# Altium schematic sheet Poseidon.SchDoc
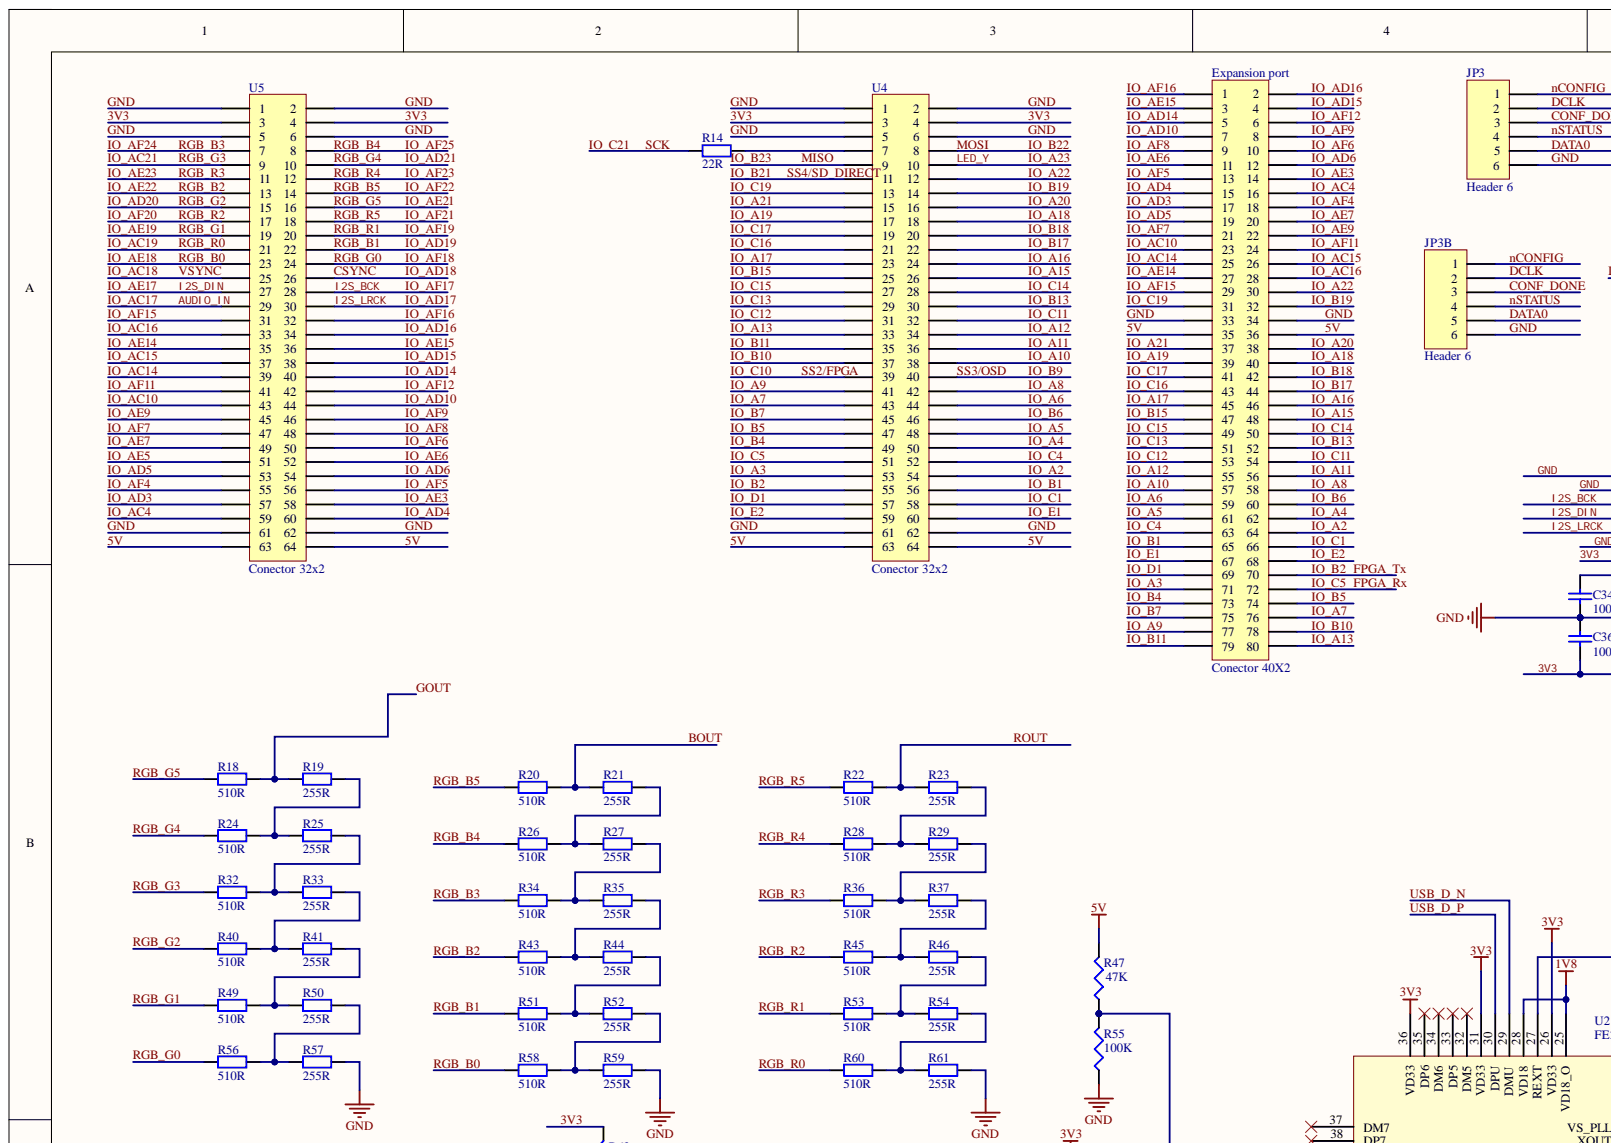
[diagram p0: Poseidon.SchDoc, top left]
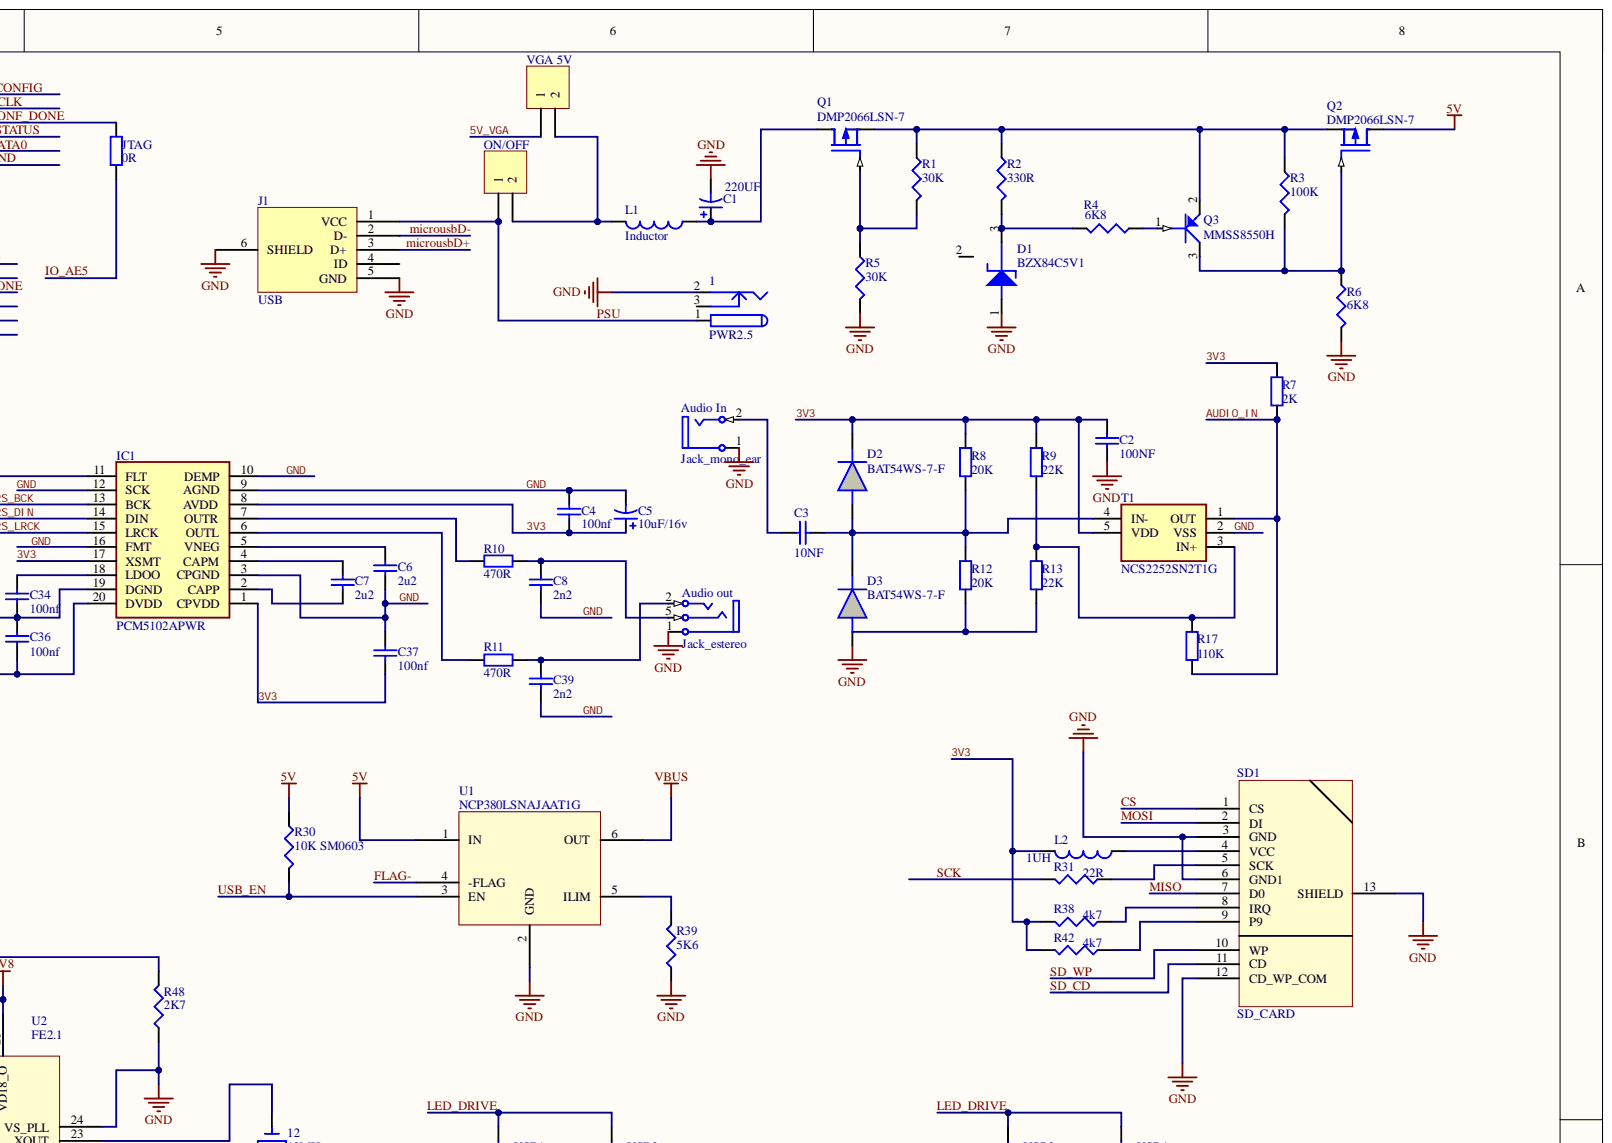
[diagram p1: Poseidon.SchDoc, top right]
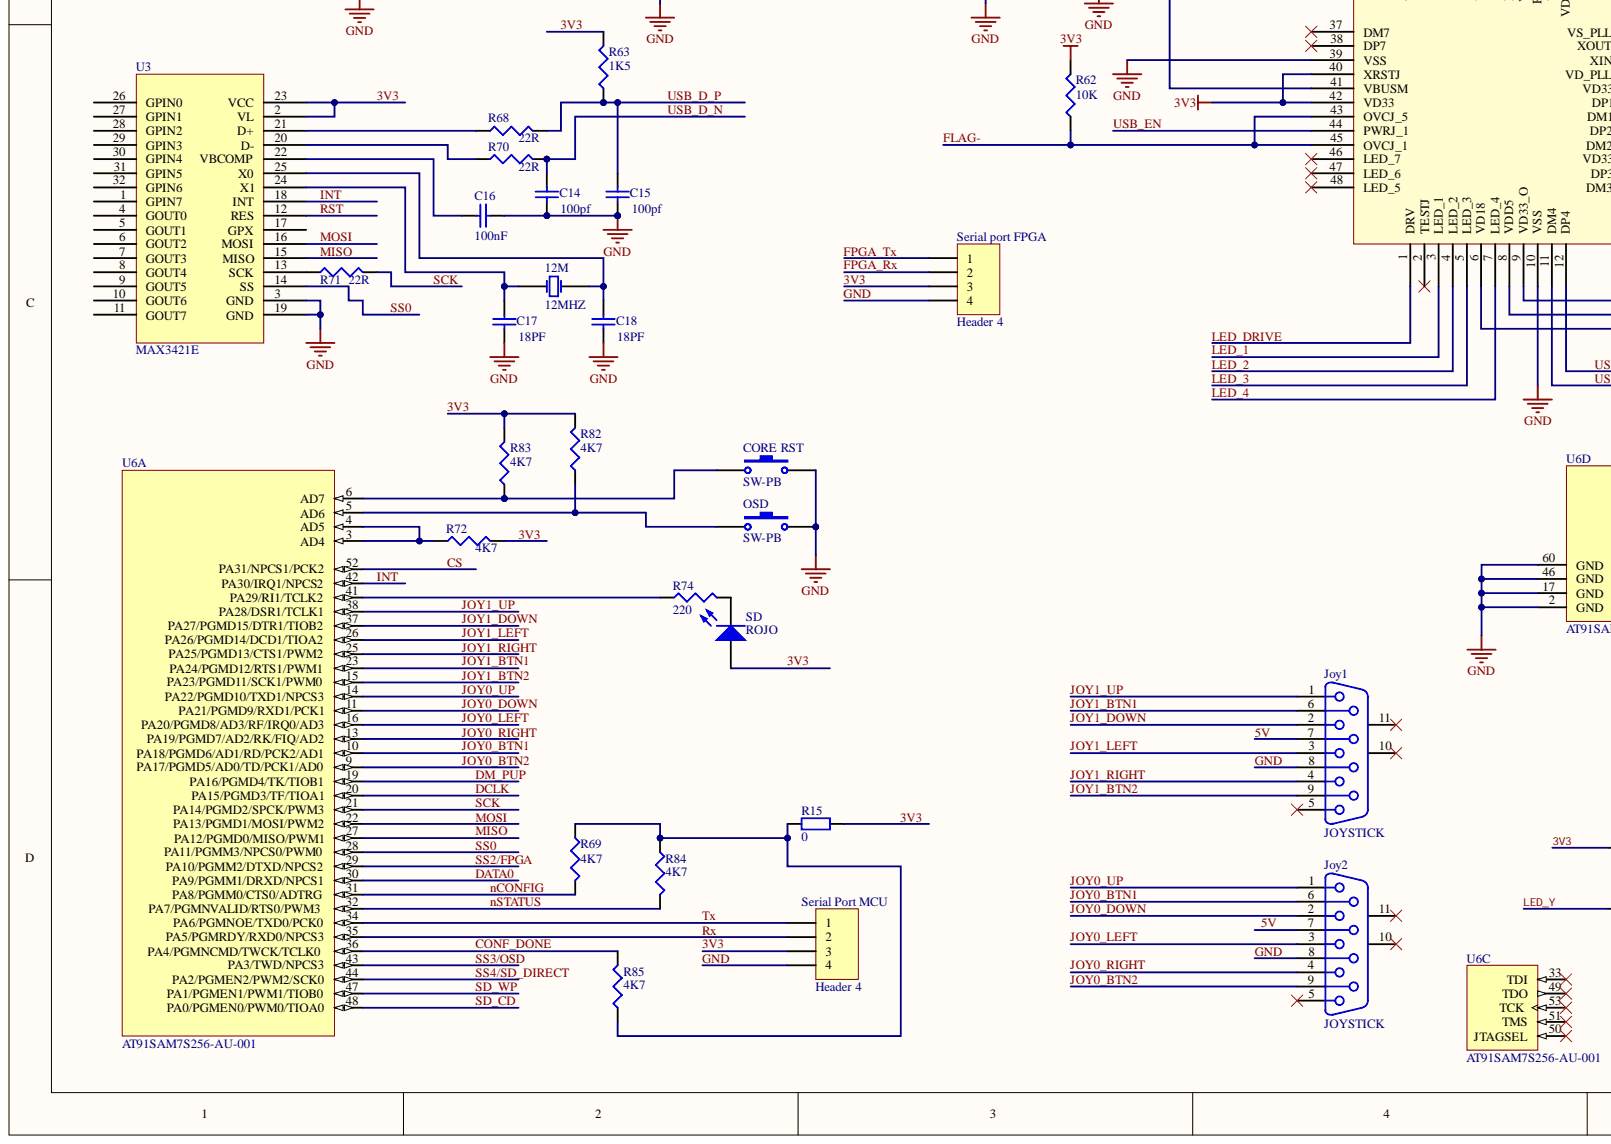
[diagram p2: Poseidon.SchDoc, bottom left]
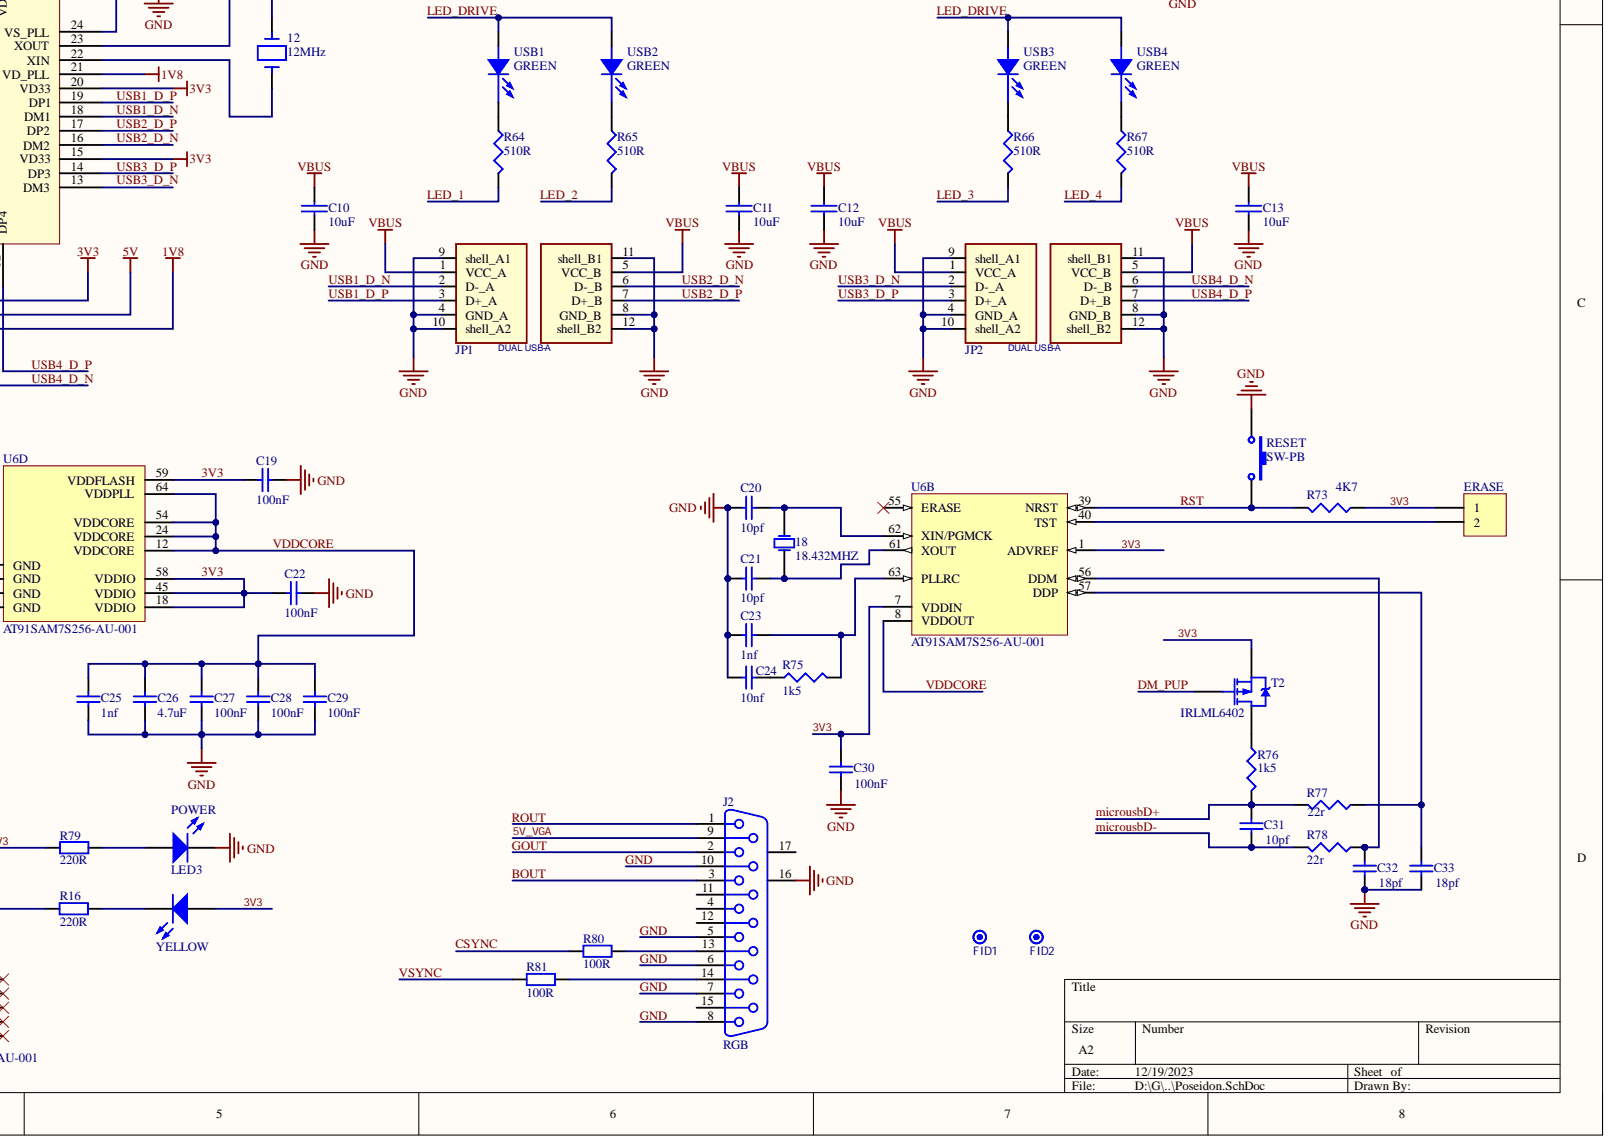
[diagram p3: Poseidon.SchDoc, bottom right]

## Parts
1  PWR2.5  [DCJACK]
12  12MHz  [HC49/4H_SMX]
12M  12MHZ  [HC49/4H_SMX]
18  18.432MHZ  [HC49/4H_SMX]
Audio_In  Jack_mono_ear  [AUDIOJACK_MANU]
Audio_out  Jack_estereo  [AUDIOJACK_MANU]
C1  220UF  [smd_elec6mm]
C2  100NF  [cap0603_manu3d]
C3  10NF  [cap0603_manu3d]
C4  100nf  [cap0603_manu3d]
C5  10uF/16v  [smd_elec3.5mm]
C6  2u2  [cap0603_manu3d]
C7  2u2  [cap0603_manu3d]
C8  2n2  [cap0603_manu3d]
C10  10uF  [SM0805]
C11  10uF  [SM0805]
C12  10uF  [SM0805]
C13  10uF  [SM0805]
C14  100pf  [cap0603_manu3d]
C15  100pf  [cap0603_manu3d]
C16  100nF  [cap0603_manu3d]
C17  18PF  [cap0603_manu3d]
C18  18PF  [cap0603_manu3d]
C19  100nF  [cap0603_manu3d]
C20  10pf  [cap0603_manu3d]
C21  10pf  [cap0603_manu3d]
C22  100nF  [cap0603_manu3d]
C23  1nf  [cap0603_manu3d]
C24  10nf  [cap0603_manu3d]
C25  1nf  [cap0603_manu3d]
C26  4.7uF  [cap0603_manu3d]
C27  100nF  [cap0603_manu3d]
C28  100nF  [cap0603_manu3d]
C29  100nF  [cap0603_manu3d]
C30  100nF  [cap0603_manu3d]
C31  10pf  [cap0603_manu3d]
C32  18pf  [cap0603_manu3d]
C33  18pf  [cap0603_manu3d]
C34  100nf  [cap0603_manu3d]
C36  100nf  [cap0603_manu3d]
C37  100nf  [cap0603_manu3d]
C39  2n2  [cap0603_manu3d]
CORE_RST  SW-PB  [TACTSWITCH90]
D1  BZX84C5V1  [SOT23]
D2  BAT54WS-7-F  [SOD2513X120N]
D3  BAT54WS-7-F  [SOD2513X120N]
ERASE  Header 2  [Pin_2v_2.56]  (value not drawn)
Expansion_port  Conector 40X2  [DIP80]
FID1  =Value  [FID_round_1mm]  (no BOM)
FID2  =Value  [FID_round_1mm]  (no BOM)
IC1  PCM5102APWR  [SOP65P640X120-20N]
J1  USB  [Micro_usb__manu]
J2  RGB  [VGA]
Joy1  JOYSTICK  [DSUB1.385-2H9B]
Joy2  JOYSTICK  [DSUB1.385-2H9B]
JP1  DUAL USB-A  [USB_STACK]
JP2  DUAL USB-A  [USB_STACK]
JP3  Header 6  [HDR1X6]
JP3B  Header 6  [HDR1X6]
JTAG  0R  [Resistor_bridge]
L1  Inductor  [RES0603_manu3d]
L2  Inductor  value 1UH  [RES0603_manu3d]
ON/OFF  Header 2  [Pin_2v_2.56]  (value not drawn)
OSD  SW-PB  [TACTSWITCH90]
POWER  LED3  [LED0805_manu]
Q1  DMP2066LSN-7  [SOT23]
Q2  DMP2066LSN-7  [SOT23]
Q3  MMSS8550H  [SOT23]
R1  30K  [RES0603_manu3d]
R2  330R  [RES0603_manu3d]
R3  100K  [RES0603_manu3d]
R4  6K8  [RES0603_manu3d]
R5  30K  [RES0603_manu3d]
R6  6K8  [RES0603_manu3d]
R7  2K  [RES0603_manu3d]
R8  20K  [RES0603_manu3d]
R9  22K  [RES0603_manu3d]
R10  470R  [RES0603_manu3d]
R11  470R  [RES0603_manu3d]
R12  20K  [RES0603_manu3d]
R13  22K  [RES0603_manu3d]
R14  22R  [RES0603_manu3d]
R15  0  [Resistor_bridge]
R16  220R  [RES0603_manu3d]
R17  110K  [RES0603_manu3d]
R18  510R  [RES0603_manu3d]
R19  255R  [RES0603_manu3d]
R20  510R  [RES0603_manu3d]
R21  255R  [RES0603_manu3d]
R22  510R  [RES0603_manu3d]
R23  255R  [RES0603_manu3d]
R24  510R  [RES0603_manu3d]
R25  255R  [RES0603_manu3d]
R26  510R  [RES0603_manu3d]
R27  255R  [RES0603_manu3d]
R28  510R  [RES0603_manu3d]
R29  255R  [RES0603_manu3d]
R30  10K SM0603  [RES0603_manu3d]
R31  value 22R  [RES0603_manu3d]  (hidden comment: Res3)
R32  510R  [RES0603_manu3d]
R33  255R  [RES0603_manu3d]
R34  510R  [RES0603_manu3d]
R35  255R  [RES0603_manu3d]
R36  510R  [RES0603_manu3d]
R37  255R  [RES0603_manu3d]
R38  value 4k7  [RES0603_manu3d]  (hidden comment: Res3)
R39  5K6  [RES0603_manu3d]
R40  510R  [RES0603_manu3d]
R41  255R  [RES0603_manu3d]
R42  value 4k7  [RES0603_manu3d]  (hidden comment: Res3)
R43  510R  [RES0603_manu3d]
R44  255R  [RES0603_manu3d]
R45  510R  [RES0603_manu3d]
R46  255R  [RES0603_manu3d]
R47  47K  [RES0603_manu3d]
R48  2K7  [RES0603_manu3d]
R49  510R  [RES0603_manu3d]
R50  255R  [RES0603_manu3d]
R51  510R  [RES0603_manu3d]
R52  255R  [RES0603_manu3d]
R53  510R  [RES0603_manu3d]
R54  255R  [RES0603_manu3d]
R55  100K  [RES0603_manu3d]
R56  510R  [RES0603_manu3d]
R57  255R  [RES0603_manu3d]
R58  510R  [RES0603_manu3d]
R59  255R  [RES0603_manu3d]
R60  510R  [RES0603_manu3d]
R61  255R  [RES0603_manu3d]
R62  10K  [RES0603_manu3d]
R63  1K5  [RES0603_manu3d]
R64  510R  [RES0603_manu3d]
R65  510R  [RES0603_manu3d]
R66  510R  [RES0603_manu3d]
R67  510R  [RES0603_manu3d]
R68  22R  [RES0603_manu3d]
R69  4K7  [RES0603_manu3d]
R70  22R  [RES0603_manu3d]
R71  22R  [RES0603_manu3d]
R72  4K7  [RES0603_manu3d]
R73  4K7  [RES0603_manu3d]
R74  220  [RES0603_manu3d]
R75  1k5  [RES0603_manu3d]
R76  1k5  [RES0603_manu3d]
R77  22r  [RES0603_manu3d]
R78  value 22r  [RES0603_manu3d]  (hidden comment: Res3)
R79  220R  [RES0603_manu3d]
R80  100R  [RES0603_manu3d]
R81  100R  [RES0603_manu3d]
R82  4K7  [RES0603_manu3d]
R83  4K7  [RES0603_manu3d]
R84  4K7  [RES0603_manu3d]
R85  4K7  [RES0603_manu3d]
RESET  SW-PB  [SWITCH]
SD  ROJO  [LED0805R]
SD1  SD_CARD  [sdsocket]
Serial_port_FPGA  Header 4  [HDR1X4]
Serial_Port_MCU  Header 4  [HDR1X4]
T1  NCS2252SN2T1G  [SOT95P275X110-5N]
T2  IRLML6402  [SOT23_L]
U1  NCP380LSNAJAAT1G  [SOT23-6LEAD]
U2  FE2.1  [LQFP48]
U3  MAX3421E  [TQFP0.5]
U4  Conector 32x2  [DIP64]
U5  Conector 32x2  [DIP64]
U6  AT91SAM7S256-AU-001  [LQFP64_N]  (parts A,B,C,D)
USB1  GREEN  [LED0805_manu]
USB2  GREEN  [LED0805_manu]
USB3  GREEN  [LED0805_manu]
USB4  GREEN  [LED0805_manu]
VGA_5V  Header 2  [Pin_2v_2.56]  (value not drawn)
YELLOW  LED3  [LED0805R]

## Nets
1V8: U2.6(VD18) U2.21(VD_PLL) U2.25(VD18_O) U2.28(VD18)
VBUS: C10.1 C11.1 C12.1 C13.1 JP1.1(VCC_A) JP1.5(VCC_B) JP2.1(VCC_A) JP2.5(VCC_B) U1.6(OUT)
3V3: C2.1 C4.2 C5.1 C19.2 C22.2 C30.2 C36.2 C37.1 D2.1 ERASE.1 IC1.1(CPVDD) IC1.8(AVDD) IC1.17(XSMT) IC1.20(DVDD) L2.2 R7.2 R8.2 R9.2 R15.2 R38.2 R42.2 R62.2 R63.2 R72.2 R73.1 R79.1 R82.2 R83.2 SD.1 Serial_port_FPGA.3 Serial_Port_MCU.3 T1.5(VDD) T2.2 U2.9(VD33_O) U2.15(VD33) U2.20(VD33) U2.26(VD33) U2.31(VD33) U2.36(VD33) U2.40(XRSTJ) U2.42(VD33) U3.2(VL) U3.23(VCC) U4.3 U4.4 U5.3 U5.4 U6.1(ADVREF) U6.7(VDDIN) U6.18(VDDIO) U6.45(VDDIO) U6.58(VDDIO) U6.59(VDDFLASH) YELLOW.1
5V: Expansion_port.35 Expansion_port.36 Joy1.7 Joy2.7 Q2.3 R30.1 R47.2 U1.1(IN) U2.8(VDD5) U4.63 U4.64 U5.63 U5.64
5V_VGA: J2.9 VGA_5V.1
AUDIO_IN: R7.1 R17.1 T1.1(OUT) U4.29
BOUT: J2.3 R20.2 R21.1
CONF_DONE: JP3.3 JP3B.3 JTAG.2 R85.2 U6.36(PA4/PGMNCMD/TWCK/TCLK0)
CS: SD1.1(CS) U6.52(PA31/NPCS1/PCK2)
CSYNC: R80.1 U4.26
DATA0: JP3.5 JP3B.5 U6.30(PA9/PGMM1/DRXD/NPCS1)
DCLK: JP3.2 JP3B.2 U6.20(PA15/PGMD3/TF/TIOA1)
DM_PUP: T2.1 U6.19(PA16/PGMD4/TK/TIOB1)
FLAG-: R62.1 U1.4(-FLAG) U2.43(OVCJ_5) U2.45(OVCJ_1)
FPGA_Rx: Expansion_port.72 Serial_port_FPGA.2 U5.51
FPGA_Tx: Expansion_port.70 Serial_port_FPGA.1 U5.55
GND: 1.2 Audio_In.1 Audio_out.1 C1.2 C2.2 C4.1 C5.2 C6.2 C8.2 C10.2 C11.2 C12.2 C13.2 C14.1 C15.1 C16.1 C17.1 C18.1 C19.1 C20.2 C21.2 C22.1 C23.2 C24.2 C25.2 C26.2 C27.2 C28.2 C29.2 C30.1 C32.1 C33.1 C34.2 C36.1 C37.2 C39.2 CORE_RST.2 D1.1 D3.2 Expansion_port.33 Expansion_port.34 IC1.3(CPGND) IC1.9(AGND) IC1.10(DEMP) IC1.11(FLT) IC1.12(SCK) IC1.16(FMT) IC1.19(DGND) J1.5(GND) J1.6(SHIELD) J2.5 J2.6 J2.7 J2.8 J2.10 J2.16 Joy1.8 Joy2.8 JP1.4(GND_A) JP1.8(GND_B) JP1.9(shell_A1) JP1.10(shell_A2) JP1.11(shell_B1) JP1.12(shell_B2) JP2.4(GND_A) JP2.8(GND_B) JP2.9(shell_A1) JP2.10(shell_A2) JP2.11(shell_B1) JP2.12(shell_B2) JP3.6 JP3B.6 OSD.2 POWER.2 R5.2 R6.2 R39.1 R48.1 R55.1 R57.2 R59.2 R61.2 RESET.1 SD1.3(GND) SD1.6(GND1) SD1.12(CD_WP_COM) SD1.13(SHIELD) Serial_port_FPGA.4 Serial_Port_MCU.4 T1.2(VSS) U1.2(GND) U2.10(VSS) U2.24(VS_PLL) U2.39(VSS) U3.3(GND) U3.19(GND) U4.1 U4.2 U4.5 U4.6 U4.61 U4.62 U5.1 U5.2 U5.5 U5.6 U5.61 U5.62 U6.2(GND) U6.17(GND) U6.46(GND) U6.60(GND)
GOUT: J2.2 R18.2 R19.1
I2S_BCK: IC1.13(BCK) U4.28
I2S_DIN: IC1.14(DIN) U4.27
I2S_LRCK: IC1.15(LRCK) U4.30
INT: U3.18(INT) U6.42(PA30/IRQ1/NPCS2)
IO_A2: Expansion_port.64 U5.54
IO_A3: Expansion_port.71 U5.53
IO_A4: Expansion_port.62 U5.50
IO_A5: Expansion_port.61 U5.48
IO_A6: Expansion_port.59 U5.44
IO_A7: Expansion_port.76 U5.43
IO_A8: Expansion_port.58 U5.42
IO_A9: Expansion_port.77 U5.41
IO_A10: Expansion_port.57 U5.38
IO_A11: Expansion_port.56 U5.36
IO_A12: Expansion_port.55 U5.34
IO_A13: Expansion_port.80 U5.33
IO_A15: Expansion_port.48 U5.26
IO_A16: Expansion_port.46 U5.24
IO_A17: Expansion_port.45 U5.23
IO_A18: Expansion_port.40 U5.18
IO_A19: Expansion_port.39 U5.17
IO_A20: Expansion_port.38 U5.16
IO_A21: Expansion_port.37 U5.15
IO_A22: Expansion_port.30 U5.12
IO_A23: R16.1 U5.10
IO_AC4: Expansion_port.16 U4.59
IO_AC10: Expansion_port.23 U4.43
IO_AC14: Expansion_port.25 U4.39
IO_AC15: Expansion_port.26 U4.37
IO_AC16: Expansion_port.28 U4.33
IO_AC18: R81.1 U4.25
IO_AC19: R60.1 U4.21
IO_AC21: R32.1 U4.9
IO_AD3: Expansion_port.17 U4.57
IO_AD4: Expansion_port.15 U4.60
IO_AD5: Expansion_port.19 U4.53
IO_AD6: Expansion_port.12 U4.54
IO_AD10: Expansion_port.7 U4.44
IO_AD14: Expansion_port.5 U4.40
IO_AD15: Expansion_port.4 U4.38
IO_AD16: Expansion_port.2 U4.34
IO_AD19: R51.1 U4.22
IO_AD20: R40.1 U4.15
IO_AD21: R24.1 U4.10
IO_AE3: Expansion_port.14 U4.58
IO_AE5: JTAG.1 U4.51
IO_AE6: Expansion_port.11 U4.52
IO_AE7: Expansion_port.20 U4.49
IO_AE9: Expansion_port.22 U4.45
IO_AE14: Expansion_port.27 U4.35
IO_AE15: Expansion_port.3 U4.36
IO_AE18: R58.1 U4.23
IO_AE19: R49.1 U4.19
IO_AE21: R18.1 U4.16
IO_AE22: R43.1 U4.13
IO_AE23: R36.1 U4.11
IO_AF4: Expansion_port.18 U4.55
IO_AF5: Expansion_port.13 U4.56
IO_AF6: Expansion_port.10 U4.50
IO_AF7: Expansion_port.21 U4.47
IO_AF8: Expansion_port.9 U4.48
IO_AF9: Expansion_port.8 U4.46
IO_AF11: Expansion_port.24 U4.41
IO_AF12: Expansion_port.6 U4.42
IO_AF15: Expansion_port.29 U4.31
IO_AF16: Expansion_port.1 U4.32
IO_AF18: R56.1 U4.24
IO_AF19: R53.1 U4.20
IO_AF20: R45.1 U4.17
IO_AF21: R22.1 U4.18
IO_AF22: R20.1 U4.14
IO_AF23: R28.1 U4.12
IO_AF24: R34.1 U4.7
IO_AF25: R26.1 U4.8
IO_B1: Expansion_port.65 U5.56
IO_B4: Expansion_port.73 U5.49
IO_B5: Expansion_port.74 U5.47
IO_B6: Expansion_port.60 U5.46
IO_B7: Expansion_port.75 U5.45
IO_B9: U5.40 U6.43(PA3/TWD/NPCS3)
IO_B10: Expansion_port.78 U5.37
IO_B11: Expansion_port.79 U5.35
IO_B13: Expansion_port.52 U5.30
IO_B15: Expansion_port.47 U5.25
IO_B17: Expansion_port.44 U5.22
IO_B18: Expansion_port.42 U5.20
IO_B19: Expansion_port.32 U5.14
IO_B21: U5.11 U6.44(PA2/PGMEN2/PWM2/SCK0)
IO_B22: SD1.2(DI) U3.16(MOSI) U5.8 U6.22(PA13/PGMD1/MOSI/PWM2)
IO_B23: SD1.7(D0) U3.15(MISO) U5.9 U6.27(PA12/PGMD0/MISO/PWM1)
IO_C1: Expansion_port.66 U5.58
IO_C4: Expansion_port.63 U5.52
IO_C10: U5.39 U6.29(PA10/PGMM2/DTXD/NPCS2)
IO_C11: Expansion_port.54 U5.32
IO_C12: Expansion_port.53 U5.31
IO_C13: Expansion_port.51 U5.29
IO_C14: Expansion_port.50 U5.28
IO_C15: Expansion_port.49 U5.27
IO_C16: Expansion_port.43 U5.21
IO_C17: Expansion_port.41 U5.19
IO_C19: Expansion_port.31 U5.13
IO_C21: R14.1 R31.2 R71.2 U6.21(PA14/PGMD2/SPCK/PWM3)
IO_D1: Expansion_port.69 U5.57
IO_E1: Expansion_port.67 U5.60
IO_E2: Expansion_port.68 U5.59
JOY0_BTN1: Joy2.6 U6.10(PA18/PGMD6/AD1/RD/PCK2/AD1)
JOY0_BTN2: Joy2.9 U6.9(PA17/PGMD5/AD0/TD/PCK1/AD0)
JOY0_DOWN: Joy2.2 U6.11(PA21/PGMD9/RXD1/PCK1)
JOY0_LEFT: Joy2.3 U6.16(PA20/PGMD8/AD3/RF/IRQ0/AD3)
JOY0_RIGHT: Joy2.4 U6.13(PA19/PGMD7/AD2/RK/FIQ/AD2)
JOY0_UP: Joy2.1 U6.14(PA22/PGMD10/TXD1/NPCS3)
JOY1_BTN1: Joy1.6 U6.23(PA24/PGMD12/RTS1/PWM1)
JOY1_BTN2: Joy1.9 U6.15(PA23/PGMD11/SCK1/PWM0)
JOY1_DOWN: Joy1.2 U6.37(PA27/PGMD15/DTR1/TIOB2)
JOY1_LEFT: Joy1.3 U6.26(PA26/PGMD14/DCD1/TIOA2)
JOY1_RIGHT: Joy1.4 U6.25(PA25/PGMD13/CTS1/PWM2)
JOY1_UP: Joy1.1 U6.38(PA28/DSR1/TCLK1)
LED_1: R64.2 U2.3(LED_1)
LED_2: R65.2 U2.4(LED_2)
LED_3: R66.2 U2.5(LED_3)
LED_4: R67.2 U2.7(LED_4)
LED_DRIVE: U2.1(DRV) USB1.1 USB2.1 USB3.1 USB4.1
microusbD+: C31.2 J1.3(D+) R76.2 R77.2
microusbD-: C31.1 J1.2(D-) R78.2
nCONFIG: JP3.1 JP3B.1 R69.2 U6.31(PA8/PGMM0/CTS0/ADTRG)
nSTATUS: JP3.4 JP3B.4 R84.2 U6.32(PA7/PGMNVALID/RTS0/PWM3)
PSU: 1.1 J1.1(VCC) ON/OFF.1
ROUT: J2.1 R22.2 R23.1
RST: R73.2 RESET.2 U3.12(RES) U6.39(NRST)
Rx: Serial_Port_MCU.2 U6.35(PA5/PGMRDY/RXD0/NPCS3)
SD_CD: SD1.11(CD) U6.48(PA0/PGMEN0/PWM0/TIOA0)
SD_WP: SD1.10(WP) U6.47(PA1/PGMEN1/PWM1/TIOB0)
SS0: U3.14(SS) U6.28(PA11/PGMM3/NPCS0/PWM0)
Tx: Serial_Port_MCU.1 U6.34(PA6/PGMNOE/TXD0/PCK0)
USB1_D_N: JP1.2(D-_A) U2.18(DM1)
USB1_D_P: JP1.3(D+_A) U2.19(DP1)
USB2_D_N: JP1.6(D-_B) U2.16(DM2)
USB2_D_P: JP1.7(D+_B) U2.17(DP2)
USB3_D_N: JP2.2(D-_A) U2.13(DM3)
USB3_D_P: JP2.3(D+_A) U2.14(DP3)
USB4_D_N: JP2.6(D-_B) U2.11(DM4)
USB4_D_P: JP2.7(D+_B) U2.12(DP4)
USB_D_N: C14.2 R70.2 U2.29(DMU)
USB_D_P: C15.2 R63.1 R68.2 U2.30(DPU)
USB_EN: R30.2 U1.3(EN) U2.44(PWRJ_1)
VDDCORE: C25.1 C26.1 C27.1 C28.1 C29.1 U6.8(VDDOUT) U6.12(VDDCORE) U6.24(VDDCORE) U6.54(VDDCORE) U6.64(VDDPLL)
Net12_1: 12.1 U2.23(XOUT)
Net12_2: 12.2 U2.22(XIN)
Net12M_1: 12M.1 C18.2 U3.25(X0)
Net12M_2: 12M.2 C17.2 U3.24(X1)
Net18_1: 18.1 C21.1 U6.61(XOUT)
Net18_2: 18.2 C20.1 U6.62(XIN/PGMCK)
NetAudio In_2: Audio_In.2 C3.2
NetAudio out_2: Audio_out.2 C39.1 R11.2
NetAudio out_5: Audio_out.5 C8.1 R10.2
NetC1_1: C1.1 L1.1 Q1.3
NetC3_1: C3.1 D2.2 D3.1 R8.1 R12.2 T1.4(IN-)
NetC6_1: C6.1 IC1.5(VNEG)
NetC7_1: C7.1 IC1.4(CAPM)
NetC7_2: C7.2 IC1.2(CAPP)
NetC16_2: C16.2 U3.22(VBCOMP)
NetC23_1: C23.1 R75.2 U6.63(PLLRC)
NetC24_1: C24.1 R75.1
NetC32_2: C32.2 R78.1 U6.56(DDM)
NetC33_2: C33.2 R77.1 U6.57(DDP)
NetC34_1: C34.1 IC1.18(LDOO)
NetCORE RST_1: CORE_RST.1 R83.1 U6.6(AD7)
NetD1_3: D1.3 R2.2 R4.2
NetERASE_2: ERASE.2 U6.40(TST)
NetIC1_6: IC1.6(OUTL) R11.1
NetIC1_7: IC1.7(OUTR) R10.1
NetJ2_13: J2.13 R80.2
NetJ2_14: J2.14 R81.2
NetL1_2: L1.2 ON/OFF.2 VGA_5V.2
NetL2_1: L2.1 SD1.4(VCC)
NetOSD_1: OSD.1 R82.1 U6.5(AD6)
NetPOWER_1: POWER.1 R79.2
NetQ1_1: Q1.1 R1.2 R5.1
NetQ1_2: Q1.2 Q2.2 Q3.2 R1.1 R2.1 R3.1
NetQ2_1: Q2.1 Q3.3 R3.2 R6.1
NetQ3_1: Q3.1 R4.1
NetR9_1: R9.1 R13.2 R17.2 T1.3(IN+)
NetR12_1: R12.1 R13.1
NetR14_2: R14.2 U5.7
NetR15_1: R15.1 R69.1 R84.1 R85.1
NetR16_2: R16.2 YELLOW.2
NetR19_2: R19.2 R24.2 R25.1
NetR21_2: R21.2 R26.2 R27.1
NetR23_2: R23.2 R28.2 R29.1
NetR25_2: R25.2 R32.2 R33.1
NetR27_2: R27.2 R34.2 R35.1
NetR29_2: R29.2 R36.2 R37.1
NetR31_1: R31.1 SD1.5(SCK)
NetR33_2: R33.2 R40.2 R41.1
NetR35_2: R35.2 R43.2 R44.1
NetR37_2: R37.2 R45.2 R46.1
NetR38_1: R38.1 SD1.8(IRQ)
NetR39_2: R39.2 U1.5(ILIM)
NetR41_2: R41.2 R49.2 R50.1
NetR42_1: R42.1 SD1.9(P9)
NetR44_2: R44.2 R51.2 R52.1
NetR46_2: R46.2 R53.2 R54.1
NetR47_1: R47.1 R55.2 U2.41(VBUSM)
NetR48_2: R48.2 U2.27(REXT)
NetR50_2: R50.2 R56.2 R57.1
NetR52_2: R52.2 R58.2 R59.1
NetR54_2: R54.2 R60.2 R61.1
NetR64_1: R64.1 USB1.2
NetR65_1: R65.1 USB2.2
NetR66_1: R66.1 USB3.2
NetR67_1: R67.1 USB4.2
NetR68_1: R68.1 U3.21(D+)
NetR70_1: R70.1 U3.20(D-)
NetR71_1: R71.1 U3.13(SCK)
NetR72_1: R72.1 U6.3(AD4) U6.4(AD5)
NetR74_1: R74.1 U6.41(PA29/RI1/TCLK2)
NetR74_2: R74.2 SD.2
NetR76_1: R76.1 T2.3

Not connected: 1 3; D1 2; J1 4(ID); J2 4 11 12 15 17; Joy1 5 10 11; Joy2 5 10 11; U2 2(TESTJ) 32(DM5) 33(DP5) 34(DM6) 35(DP6) 37(DM7) 38(DP7) 46(LED_7) 47(LED_6) 48(LED_5); U3 1(GPIN7) 4(GOUT0) 5(GOUT1) 6(GOUT2) 7(GOUT3) 8(GOUT4) 9(GOUT5) 10(GOUT6) 11(GOUT7) 17(GPX) 26(GPIN0) 27(GPIN1) 28(GPIN2) 29(GPIN3) 30(GPIN4) 31(GPIN5) 32(GPIN6); U6 33(TDI) 49(TDO) 50(JTAGSEL) 51(TMS) 53(TCK) 55(ERASE)

## Library data of the parts
1 = PWR2.5: Low Voltage Power Supply Connector; Component Kind: Standard; ComponentLink1Description: Manufacturer Link; ComponentLink1URL: http://domino.kycon.com/internet/Products.html; DatasheetDocument: 09-May-2002; Library Name: Miscellaneous Connectors.IntLib; Published: 17-Dec-2003; Publisher: Altium Limited
12 = XTAL: Component Kind: Standard; Library Name: LIBRARY1_Library.SchLib
12M 18 = HC49/4H_SMX: Surface Mount Quartz Crystal; Note: PCB layout complies with manufacturer's recommendation.; Component Kind: Standard; ComponentLink1Description: Manufacturer Link; ComponentLink1URL: http://www.cmac.com/mt/; ComponentLink2Description: Datasheet; ComponentLink2URL: http://www.cmac.com/mt/databook/crystals/smd/hc49_4h_smx.pdf; DatasheetDocument: 18-Oct-1999; Library Name: Zx-Uno_Project.IntLib; PackageDocument: 18-Oct-1999; PackageReference: HC49/4H_SMX; Published: 17-Jul-2002; Publisher: Altium Limited
Audio_In = Phonejack2: Jack Socket, 1/4" [6.5mm], Thru-Hole, Vertical, 2-Conductor Open Circuit; DrillAndSlotSize: Corresponds to nominal value.; PackageDocument: 2003; PCB_Layout: Complies with manufacturer's recommendation.; Component Kind: Standard; ComponentLink1Description: Manufacturer Link; ComponentLink1URL: http://www.cliffuk.co.uk/; DatasheetDocument: 2003; LatestRevisionDate: 15-Jul-2004; LatestRevisionNote: Component Replaced.; Library Name: Zx-Uno_Project.IntLib; PackageReference: S1VS_SNS; Publisher: Altium Limited
Audio_out = Phonejack3: Jack Socket, 1/4" [6.5mm], Thru-Hole, Vertical, 3-Conductor Open Circuit (Non-Normalling); DrillAndSlotSize: Corresponds to nominal value.; PackageDocument: 2003; PCB_Layout: Complies with manufacturer's recommendation.; Component Kind: Standard; ComponentLink1Description: Manufacturer Link; ComponentLink1URL: http://www.cliffuk.co.uk/; DatasheetDocument: 2003; LatestRevisionDate: 15-Jul-2004; LatestRevisionNote: Component Replaced.; Library Name: Miscellaneous Connectors.IntLib; PackageReference: S1VS_SSS; Published: 15-Jul-2004; Publisher: Altium Limited
C1 C5 = Cap Pol1: Polarized Capacitor (Radial); Component Kind: Standard; LatestRevisionDate: 17-Jul-2002; LatestRevisionNote: Re-released for DXP Platform.; Library Name: Miscellaneous Devices.IntLib; PackageDescription: Polarized Capacitor (Radial); 2 Leads; PackageReference: RB7.6-15; Published: 8-Jun-2000; Signal Integrity: Cap Pol; Simulation: CAP; Publisher: Altium Limited
  C1: Value: 220UF
  C5: Value: 10uF/16v
C2 C3 C14-C18 = Cap Semi: Capacitor (Semiconductor SIM Model); Component Kind: Standard; LatestRevisionDate: 29-May-2009; LatestRevisionNote: IPC-7351 Footprint Added.; Library Name: Miscellaneous Devices.IntLib; PackageDescription: Chip Capacitor; PackageReference: C1206; Published: 8-Jun-2000; Publisher: Altium Limited
  C2: Signal Integrity: Cap Semi; Simulation: CAP; Value: 100NF
  C3: Signal Integrity: Cap Semi; Simulation: CAP; Value: 10NF
  C14: Signal Integrity: Cap Semi; Simulation: CAP; Value: 100pf
  C15: Value: 100pf
  C16: Signal Integrity: Cap Semi; Simulation: CAP; Value: 100nF
  C17 C18: Signal Integrity: Cap Semi; Simulation: CAP; Value: 18PF
C4 C6-C8 C34 C36 C37 C39 = Cap: C33; Component Kind: Standard; LatestRevisionDate: 17-Jul-2002; LatestRevisionNote: Re-released for DXP Platform.; Library Name: Zx-Uno_Project.IntLib; PackageDescription: Radial Cap, Thru-Hole; 2 Leads; 0.3 in Pin Spacing; PackageReference: RAD-0.3; Published: 8-Jun-2000; Signal Integrity: Cap; Simulation: CAP; Publisher: Altium Limited
  C4 C34 C36 C37: Value: 100nf
  C6 C7: Value: 2u2
  C8 C39: Value: 2n2
C10-C13 = C: Component Kind: Standard; Library Name: LIBRARY1_Library.SchLib
C19 C22 C27-C30 = Cap Semi: CAP0805 100NF; Component Kind: Standard; LatestRevisionDate: 29-May-2009; LatestRevisionNote: IPC-7351 Footprint Added.; Library Name: Miscellaneous Devices.IntLib; PackageDescription: Chip Capacitor; PackageReference: C1206; Published: 8-Jun-2000; Signal Integrity: Cap Semi; Simulation: CAP; Value: 100nF; Publisher: Altium Limited
C20 C21 = Cap Semi: CAP0805 10PF; Component Kind: Standard; LatestRevisionDate: 29-May-2009; LatestRevisionNote: IPC-7351 Footprint Added.; Library Name: Miscellaneous Devices.IntLib; PackageDescription: Chip Capacitor; PackageReference: C1206; Published: 8-Jun-2000; Signal Integrity: Cap Semi; Simulation: CAP; Value: 10pf; Publisher: Altium Limited
C23 C25 = Cap Semi: CAP0805 1NF; Component Kind: Standard; LatestRevisionDate: 29-May-2009; LatestRevisionNote: IPC-7351 Footprint Added.; Library Name: Miscellaneous Devices.IntLib; PackageDescription: Chip Capacitor; PackageReference: C1206; Published: 8-Jun-2000; Signal Integrity: Cap Semi; Simulation: CAP; Value: 1nf; Publisher: Altium Limited
C24 = Cap Semi: CAP0805 10NF; Component Kind: Standard; LatestRevisionDate: 29-May-2009; LatestRevisionNote: IPC-7351 Footprint Added.; Library Name: Miscellaneous Devices.IntLib; PackageDescription: Chip Capacitor; PackageReference: C1206; Published: 8-Jun-2000; Signal Integrity: Cap Semi; Simulation: CAP; Value: 10nf; Publisher: Altium Limited
C26 = Cap Semi: CAP0805 2U2; Component Kind: Standard; LatestRevisionDate: 29-May-2009; LatestRevisionNote: IPC-7351 Footprint Added.; Library Name: Miscellaneous Devices.IntLib; PackageDescription: Chip Capacitor; PackageReference: C1206; Published: 8-Jun-2000; Signal Integrity: Cap Semi; Simulation: CAP; Value: 4.7uF; Publisher: Altium Limited
C31-C33 = Cap Semi: CAP0805 18PF; Component Kind: Standard; LatestRevisionDate: 29-May-2009; LatestRevisionNote: IPC-7351 Footprint Added.; Library Name: Miscellaneous Devices.IntLib; PackageDescription: Chip Capacitor; PackageReference: C1206; Published: 8-Jun-2000; Signal Integrity: Cap Semi; Simulation: CAP; Publisher: Altium Limited
  C31: Value: 10pf
  C32 C33: Value: 18pf
CORE_RST OSD RESET = SW-PB: Switch; Component Kind: Standard; LatestRevisionDate: 17-Jul-2002; LatestRevisionNote: Re-released for DXP Platform.; Library Name: Miscellaneous Devices.IntLib; PackageDescription: Switch; 2 Leads; PackageReference: SPST-2; Published: 24-Mar-1999; Publisher: Altium Limited
D1 = ZENNER_SOT23: Component Kind: Standard; Library Name: LIBRARY1_Library.SchLib
D2 D3 = BAT54WS-7-F: Diodes Inc BAT54WS-7-F, SMT Schottky Switching Diode, 30V 200mA, 5ns, 2-Pin SOD-323; Manufacturer_Name: Diodes Inc.; Geometry.Height: 1.2mm; Component Kind: Standard; Library Name: BAT54WS-7-F.SchLib; Manufacturer_Part_Number: BAT54WS-7-F; Mouser Part Number: 621-BAT54WS-F; Mouser Price/Stock: https://www.mouser.co.uk/ProductDetail/Diodes-Incorporated/BAT54WS-7-F?qs=BJo294706GxanB6a%2FKrrdw%3D%3D
ERASE ON/OFF VGA_5V = Header 2: Header, 2-Pin; Component Kind: Standard; LatestRevisionDate: 17-Jul-2002; LatestRevisionNote: Re-released for DXP Platform.; Library Name: Miscellaneous Connectors.IntLib; Signal Integrity: Connector; Publisher: Altium Limited
FID1 FID2 = FID_round_1mm: Fiducial round 1mm; Database Table Name: SpecialSymbols; Quantity: 1; Library Name: Bonnel_Lib.DbLib
IC1 = PCM5102APWR: 2VRMS DirectPath, 112dB Audio Stereo DAC with 32-bit, 384kHz PCM Interface; Manufacturer_Name: Texas Instruments; Geometry.Height: 1.2mm; Component Kind: Standard; Datasheet Link: https://componentsearchengine.com/Datasheets/2/PCM5102APWR.pdf; Library Name: PCM5102APWR.SchLib; Manufacturer_Part_Number: PCM5102APWR; Mouser Part Number: 595-PCM5102APWR; Mouser Price/Stock: https://www.mouser.co.uk/ProductDetail/Texas-Instruments/PCM5102APWR?qs=E2%2FxqS9xjzrfECkwEYoiyg%3D%3D
J2 = D Connector 15: DS1038-15FBNSIA74; Gender: Receptacle; PackageDocument: O; Positions: 15; Component Kind: Standard; DatasheetDocument: 1-Sep-99; LatestRevisionDate: 17-Jul-2002; LatestRevisionNote: Re-released for DXP Platform.; Library Name: Miscellaneous Connectors.IntLib; Orientation: Right Angle; PackageReference: 788757; Published: 15-Jun-2001; Signal Integrity: Connector; Publisher: Altium Limited
Joy1 Joy2 = D Connector 9: DS1037-09M; Gender: Receptacle; PackageDocument: O; Positions: 9; Component Kind: Standard; DatasheetDocument: 1-Sep-1999; LatestRevisionDate: 17-Jul-2002; LatestRevisionNote: Re-released for DXP Platform.; Library Name: Zx-Uno_Project.IntLib; Orientation: Right Angle; PackageReference: 788750; Published: 15-Jun-2001; Signal Integrity: Connector; Publisher: Altium Limited
JP1 JP2 = USB-A_DUAL: Component Kind: Standard; Library Name: LIBRARY1_Library.SchLib
JP3 JP3B = Header 6: Header, 6-Pin; Component Kind: Standard; LatestRevisionDate: 17-Jul-2002; LatestRevisionNote: Re-released for DXP Platform.; Library Name: Miscellaneous Connectors.IntLib; Signal Integrity: Connector; Publisher: Altium Limited
JTAG R18-R29 R32-R37 R40 R41 R43-R46 R49-R54 R56-R61 = Res2: Component Kind: Standard; LatestRevisionDate: 17-Jul-2002; LatestRevisionNote: Re-released for DXP Platform.; Library Name: Zx-Uno_Project.IntLib; PackageDescription: Axial Device, Thru-Hole; 2 Leads; 0.4 in Pin Spacing; PackageReference: AXIAL-0.4; Published: 8-Jun-2000; Signal Integrity: Res; Simulation: RESISTOR; Publisher: Altium Limited
  JTAG: Value: 0R
  R18 R20 R22 R24 R26 R28 R32 R34 R36 R40 R43 R45 R49 R51 R53 R56 R58 R60: Description: 510R; Value: 510R
  R19 R21 R23 R25 R27 R29 R33 R35 R37 R41 R44 R46 R50 R52 R54 R57 R59 R61: Description: 255R; Value: 255R
L1 = Inductor: Code_IEC: 1005; Value: 10mH; Code_JEITA: 0402; Component Kind: Standard; Description: Inductor; LatestRevisionDate: 29-May-2009; LatestRevisionNote: IPC-7351 Footprint Added.; Library Name: Miscellaneous Devices.IntLib; PackageDescription: Chip Inductor; PackageReference: 0402-A; Published: 8-Jun-2000; Signal Integrity: Inductor; Simulation: INDUCTOR; Publisher: Altium Limited
L2 = Inductor: Code_IEC: 1005; Code_JEITA: 0402; Component Kind: Standard; Description: Inductor; LatestRevisionDate: 29-May-2009; LatestRevisionNote: IPC-7351 Footprint Added.; Library Name: Miscellaneous Devices.IntLib; PackageDescription: Chip Inductor; PackageReference: 0402-A; Published: 8-Jun-2000; Signal Integrity: Inductor; Simulation: INDUCTOR; Publisher: Altium Limited
POWER YELLOW = LED3: Typical BLUE SiC LED; Component Kind: Standard; DatasheetVersion: 16-Apr-2002; LatestRevisionDate: 17-Dec-2003; LatestRevisionNote: PCB Footprint replaced.; Library Name: Miscellaneous Devices.IntLib; PackageDescription: SM LED; 2 C-Bend Leads; Body 3.5 x 2.8 x 1.9 mm, inc leads (LxWxH typ); PackageReference: 3.5X2.8X1.9; PackageVersion: 16-Apr-2002; Published: 8-Jun-2000; Publisher: Altium Limited
Q1 Q2 = MOSFET P: Component Kind: Standard; Library Name: LIBRARY1_Library.SchLib
Q3 = BC856AL: Component Kind: Standard; Library Name: LIBRARY1_Library.SchLib
R1-R6 R30 R39 R47 R48 R55 R62 R64-R67 = RES: Component Kind: Standard; Library Name: LIBRARY1_Library.SchLib
R7 R9 R13 R17 = Res2: 1K; Component Kind: Standard; LatestRevisionDate: 17-Jul-2002; LatestRevisionNote: Re-released for DXP Platform.; Library Name: Zx-Uno_Project.IntLib; PackageDescription: Axial Device, Thru-Hole; 2 Leads; 0.4 in Pin Spacing; PackageReference: AXIAL-0.4; Published: 8-Jun-2000; Signal Integrity: Res; Simulation: RESISTOR; Publisher: Altium Limited
  R7: Value: 2K
  R9 R13: Value: 22K
  R17: Value: 110K
R8 = Res2: 680R; Component Kind: Standard; LatestRevisionDate: 17-Jul-2002; LatestRevisionNote: Re-released for DXP Platform.; Library Name: Zx-Uno_Project.IntLib; PackageDescription: Axial Device, Thru-Hole; 2 Leads; 0.4 in Pin Spacing; PackageReference: AXIAL-0.4; Published: 8-Jun-2000; Signal Integrity: Res; Simulation: RESISTOR; Value: 20K; Publisher: Altium Limited
R10 R11 = Res2: 4K7; Component Kind: Standard; LatestRevisionDate: 17-Jul-2002; LatestRevisionNote: Re-released for DXP Platform.; Library Name: Zx-Uno_Project.IntLib; PackageDescription: Axial Device, Thru-Hole; 2 Leads; 0.4 in Pin Spacing; PackageReference: AXIAL-0.4; Published: 8-Jun-2000; Signal Integrity: Res; Simulation: RESISTOR; Value: 470R; Publisher: Altium Limited
R12 = Res2: 10K; Component Kind: Standard; LatestRevisionDate: 17-Jul-2002; LatestRevisionNote: Re-released for DXP Platform.; Library Name: Zx-Uno_Project.IntLib; PackageDescription: Axial Device, Thru-Hole; 2 Leads; 0.4 in Pin Spacing; PackageReference: AXIAL-0.4; Published: 8-Jun-2000; Signal Integrity: Res; Simulation: RESISTOR; Value: 20K; Publisher: Altium Limited
R14 = Res2: 510R; Component Kind: Standard; LatestRevisionDate: 17-Jul-2002; LatestRevisionNote: Re-released for DXP Platform.; Library Name: Zx-Uno_Project.IntLib; PackageDescription: Axial Device, Thru-Hole; 2 Leads; 0.4 in Pin Spacing; PackageReference: AXIAL-0.4; Published: 8-Jun-2000; Signal Integrity: Res; Simulation: RESISTOR; Value: 22R; Publisher: Altium Limited
R15 = Res2: 0603; Component Kind: Standard; LatestRevisionDate: 17-Jul-2002; LatestRevisionNote: Re-released for DXP Platform.; Library Name: Miscellaneous Devices.IntLib; PackageDescription: Axial Device, Thru-Hole; 2 Leads; 0.4 in Pin Spacing; PackageReference: AXIAL-0.4; Published: 8-Jun-2000; Signal Integrity: Res; Simulation: RESISTOR; Value: 0; Publisher: Altium Limited
R16 R79-R81 = Res2: 75R; Component Kind: Standard; LatestRevisionDate: 17-Jul-2002; LatestRevisionNote: Re-released for DXP Platform.; Library Name: Miscellaneous Devices.IntLib; PackageDescription: Axial Device, Thru-Hole; 2 Leads; 0.4 in Pin Spacing; PackageReference: AXIAL-0.4; Published: 8-Jun-2000; Signal Integrity: Res; Simulation: RESISTOR; Publisher: Altium Limited
  R16 R79: Value: 220R
  R80 R81: Value: 100R
R31 R38 R42 R63 R68-R72 R74 R75 R82-R85 = Res3: Resistor; Component Kind: Standard; LatestRevisionDate: 29-May-2009; LatestRevisionNote: IPC-7351 Footprint Added.; Library Name: Miscellaneous Devices.IntLib; PackageDescription: Chip Resistor; PackageReference: J1-0603; Published: 8-Jun-2000; Signal Integrity: Res; Simulation: RESISTOR; Publisher: Altium Limited
  R63: Value: 1K5
  R68 R70 R71: Value: 22R
  R69 R72 R82-R85: Value: 4K7
  R74: Value: 220
  R75: Value: 1k5
R73 = Res3: RES0805 4K7; Component Kind: Standard; LatestRevisionDate: 29-May-2009; LatestRevisionNote: IPC-7351 Footprint Added.; Library Name: Miscellaneous Devices.IntLib; PackageDescription: Chip Resistor; PackageReference: J1-0603; Published: 8-Jun-2000; Signal Integrity: Res; Simulation: RESISTOR; Value: 4K7; Publisher: Altium Limited
R76 = Res3: RES0805 1K5; Component Kind: Standard; LatestRevisionDate: 29-May-2009; LatestRevisionNote: IPC-7351 Footprint Added.; Library Name: Miscellaneous Devices.IntLib; PackageDescription: Chip Resistor; PackageReference: J1-0603; Published: 8-Jun-2000; Signal Integrity: Res; Simulation: RESISTOR; Value: 1k5; Publisher: Altium Limited
R77 R78 = Res3: RES0805 22R; Component Kind: Standard; LatestRevisionDate: 29-May-2009; LatestRevisionNote: IPC-7351 Footprint Added.; Library Name: Miscellaneous Devices.IntLib; PackageDescription: Chip Resistor; PackageReference: J1-0603; Published: 8-Jun-2000; Signal Integrity: Res; Simulation: RESISTOR; Publisher: Altium Limited
  R77: Value: 22r
SD = LED2: Typical RED, GREEN, YELLOW, AMBER GaAs LED; Component Kind: Standard; LatestRevisionDate: 17-Jul-2002; LatestRevisionNote: Re-released for DXP Platform.; Library Name: Miscellaneous Devices.IntLib; PackageDescription: SM LED; 2 Flat Leads; PackageReference: 3.2X1.6X1.1; PackageVersion: Feb-2002; Published: 8-Jun-2000; Simulation: LED2; Publisher: Altium Limited
Serial_port_FPGA Serial_Port_MCU = Header 4: Header, 4-Pin; Component Kind: Standard; LatestRevisionDate: 17-Jul-2002; LatestRevisionNote: Re-released for DXP Platform.; Library Name: Miscellaneous Connectors.IntLib; Signal Integrity: Connector; Publisher: Altium Limited
T1 = NCS2252SN2T1G: Analog Comparators SINGLE OPEN DRAIN OUTPUT; Manufacturer_Name: onsemi; Geometry.Height: 1.1mm; Component Kind: Standard; Datasheet Link: https://www.onsemi.com/pub/Collateral/NCS2250-D.PDF; Library Name: NCS2252SN2T1G.SchLib; Manufacturer_Part_Number: NCS2252SN2T1G; Mouser Part Number: 863-NCS2252SN2T1G; Mouser Price/Stock: https://www.mouser.co.uk/ProductDetail/onsemi/NCS2252SN2T1G?qs=MLItCLRbWsxFyjytIqyaFQ%3D%3D
T2 = IRLML6302: HEXFET Power MOSFET; Component Kind: Standard; ComponentLink1Description: Manufacturer Link; ComponentLink1URL: http://www.irf.com/; ComponentLink2Description: Datasheet; ComponentLink2URL: http://www.irf.com/product-info/datasheets/data/irlml6302.pdf; DatasheetVersion: Aug-1997; LatestRevisionDate: 20-Nov-2009; LatestRevisionNote: IPC-7351 Footprint Added.; Library Name: IR Discrete MOSFET - Power.IntLib; PackageDescription: 3-Pin SOT-23 Package 0.95 mm Pitch, 2.3 mm Lead Span; PackageReference: SOT-23; Published: 8-Jun-2000; Publisher: Altium Limited
U6 = AT91SAM7S256-AU-001: AT91 ARM Thumb-based 32-bit Microcontroller, 256KB Flash, 64KB SRAM, 64-Pin LQFP, 11-ch. PDC, Industrial Grade (-40°C to 85°C), Pb-Free; Code_JEDEC: MS-026-BCD; Component Kind: Standard; ComponentLink1Description: Manufacturer Link; ComponentLink1URL: http://www.atmel.com/products/AT91/default.asp?; ComponentLink2Description: AT91SAM7S Series Datasheet; ComponentLink2URL: http://www.atmel.com/dyn/resources/prod_documents/doc6175.pdf; ComponentLink3Description: AT91SAM7S Series Summary; ComponentLink3URL: http://www.atmel.com/dyn/resources/prod_documents/6175s.pdf; ComponentLink4Description: ARM7TDMI Reference Manual; ComponentLink4URL: http://www.atmel.com/dyn/resources/prod_documents/DDI0029G_7TDMI_R3_trm.pdf; ComponentLink5Description: Atmel.com Home; ComponentLink5URL: http://www.atmel.com; DatasheetVersion: revJ, Dec-2009; Library Name: Atmel Microcontroller 32-Bit ARM.IntLib; PackageDescription: 64-Pin Thin Quad Flat Pack 0.5 mm Pitch; PackageReference: LQFP64; PackageVersion: Apr-2006; Published: 16-Oct-2006; Supplier 1: Digi-Key; Supplier 2: Farnell; Supplier 3: Farnell; Supplier 4: Mouser; Supplier Part Number 1: AT91SAM7S256AU001-ND; Supplier Part Number 2: 1095460; Supplier Part Number 3: 1528287; Supplier Part Number 4: 556-A91SAM7S256AU001; Publisher: Altium Limited
USB1-USB4 = LED: Component Kind: Standard; Library Name: LIBRARY1_Library.SchLib
Expansion_port = Conector 40X2: Component Kind: Standard; Library Name: QMTech board.SCHLIB
J1 = USB: Component Kind: Standard; Library Name: Zx-Uno_Project.SCHLIB
SD1 = SD_CARD: Component Kind: Standard; Library Name: Schlib1.SchLib
U1 = NCP380LSNAJAAT1G: Component Kind: Standard; Library Name: LIBRARY1_Library.SchLib
U2 = FE2.1: Component Kind: Standard; Library Name: LIBRARY1_Library.SchLib
U3 = MAX3421E: Component Kind: Standard; Description: MAX3421E; Library Name: Schlib1.SchLib
U4 U5 = Conector 32x2: Component Kind: Standard; Library Name: QMTech board.SCHLIB
